# Altium schematic sheet FPGA_part3.SchDoc
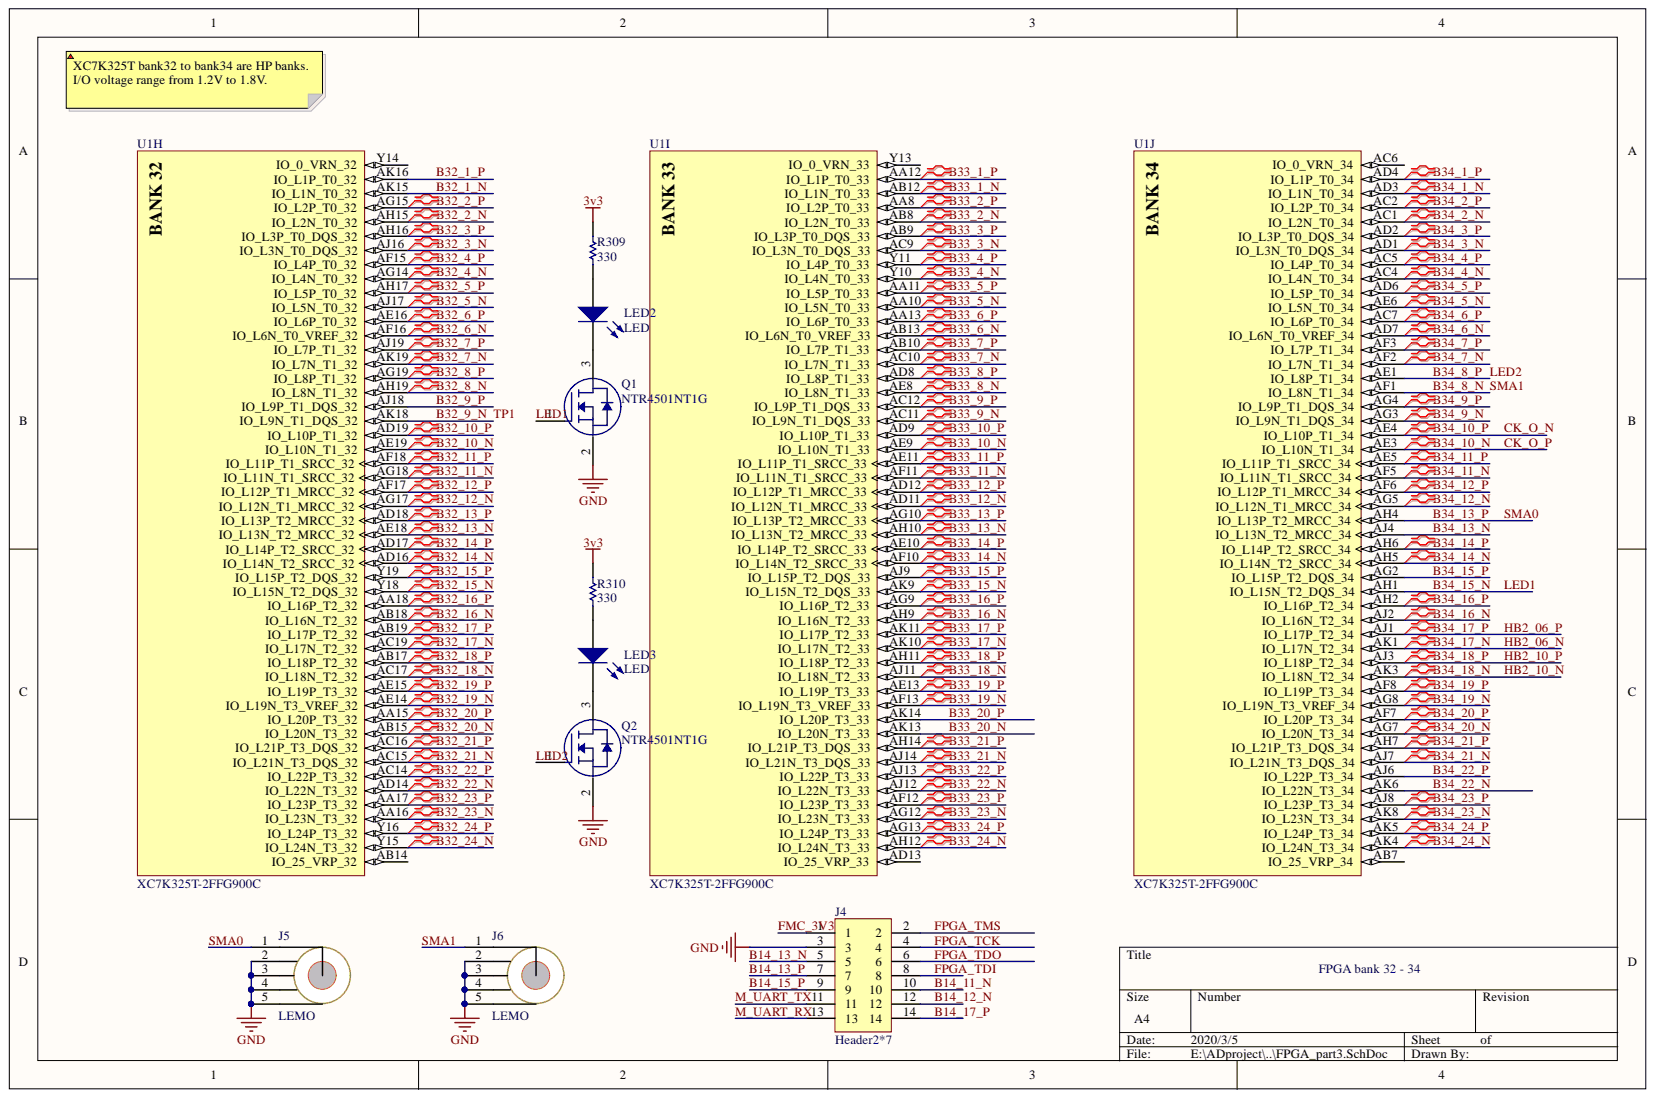
[diagram p0: FPGA_part3.SchDoc]

## Parts
J4  Header2*7  [HDR2X7]
J5  LEMO  [LEMO_00]
J6  LEMO  [LEMO_00]
LED2  LED  [led_0603r]
LED3  LED  [led_0603r]
Q1  NTR4501NT1G  [sot-23]
Q2  NTR4501NT1G  [sot-23]
R309  value 330  [0402]
R310  value 330  [0402]
U1  XC7K325T-2FFG900C  Generic Part Number: XC7K325T  [FFG900]  (parts H,I,J)

## Nets
3v3: R309.2 R310.2
GND: J4.3 J5.2 J5.3 J5.4 J5.5 J6.2 J6.3 J6.4 J6.5 Q1.2 Q2.2
B14_11_N: J4.10
B14_12_N: J4.12
B14_13_N: J4.5
B14_13_P: J4.7
B14_15_P: J4.9
B14_17_P: J4.14
B32_1_N: U1.AK15(IO_L1N_T0_32)
B32_1_P: U1.AK16(IO_L1P_T0_32)
B32_2_N: U1.AH15(IO_L2N_T0_32)
B32_2_P: U1.AG15(IO_L2P_T0_32)
B32_3_N: U1.AJ16(IO_L3N_T0_DQS_32)
B32_3_P: U1.AH16(IO_L3P_T0_DQS_32)
B32_4_N: U1.AG14(IO_L4N_T0_32)
B32_4_P: U1.AF15(IO_L4P_T0_32)
B32_5_N: U1.AJ17(IO_L5N_T0_32)
B32_5_P: U1.AH17(IO_L5P_T0_32)
B32_6_N: U1.AF16(IO_L6N_T0_VREF_32)
B32_6_P: U1.AE16(IO_L6P_T0_32)
B32_7_N: U1.AK19(IO_L7N_T1_32)
B32_7_P: U1.AJ19(IO_L7P_T1_32)
B32_8_N: U1.AH19(IO_L8N_T1_32)
B32_8_P: U1.AG19(IO_L8P_T1_32)
B32_9_N: U1.AK18(IO_L9N_T1_DQS_32)
B32_9_P: U1.AJ18(IO_L9P_T1_DQS_32)
B32_10_N: U1.AE19(IO_L10N_T1_32)
B32_10_P: U1.AD19(IO_L10P_T1_32)
B32_11_N: U1.AG18(IO_L11N_T1_SRCC_32)
B32_11_P: U1.AF18(IO_L11P_T1_SRCC_32)
B32_12_N: U1.AG17(IO_L12N_T1_MRCC_32)
B32_12_P: U1.AF17(IO_L12P_T1_MRCC_32)
B32_13_N: U1.AE18(IO_L13N_T2_MRCC_32)
B32_13_P: U1.AD18(IO_L13P_T2_MRCC_32)
B32_14_N: U1.AD16(IO_L14N_T2_SRCC_32)
B32_14_P: U1.AD17(IO_L14P_T2_SRCC_32)
B32_15_N: U1.Y18(IO_L15N_T2_DQS_32)
B32_15_P: U1.Y19(IO_L15P_T2_DQS_32)
B32_16_N: U1.AB18(IO_L16N_T2_32)
B32_16_P: U1.AA18(IO_L16P_T2_32)
B32_17_N: U1.AC19(IO_L17N_T2_32)
B32_17_P: U1.AB19(IO_L17P_T2_32)
B32_18_N: U1.AC17(IO_L18N_T2_32)
B32_18_P: U1.AB17(IO_L18P_T2_32)
B32_19_N: U1.AE14(IO_L19N_T3_VREF_32)
B32_19_P: U1.AE15(IO_L19P_T3_32)
B32_20_N: U1.AB15(IO_L20N_T3_32)
B32_20_P: U1.AA15(IO_L20P_T3_32)
B32_21_N: U1.AC15(IO_L21N_T3_DQS_32)
B32_21_P: U1.AC16(IO_L21P_T3_DQS_32)
B32_22_N: U1.AD14(IO_L22N_T3_32)
B32_22_P: U1.AC14(IO_L22P_T3_32)
B32_23_N: U1.AA16(IO_L23N_T3_32)
B32_23_P: U1.AA17(IO_L23P_T3_32)
B32_24_N: U1.Y15(IO_L24N_T3_32)
B32_24_P: U1.Y16(IO_L24P_T3_32)
B33_1_N: U1.AB12(IO_L1N_T0_33)
B33_1_P: U1.AA12(IO_L1P_T0_33)
B33_2_N: U1.AB8(IO_L2N_T0_33)
B33_2_P: U1.AA8(IO_L2P_T0_33)
B33_3_N: U1.AC9(IO_L3N_T0_DQS_33)
B33_3_P: U1.AB9(IO_L3P_T0_DQS_33)
B33_4_N: U1.Y10(IO_L4N_T0_33)
B33_4_P: U1.Y11(IO_L4P_T0_33)
B33_5_N: U1.AA10(IO_L5N_T0_33)
B33_5_P: U1.AA11(IO_L5P_T0_33)
B33_6_N: U1.AB13(IO_L6N_T0_VREF_33)
B33_6_P: U1.AA13(IO_L6P_T0_33)
B33_7_N: U1.AC10(IO_L7N_T1_33)
B33_7_P: U1.AB10(IO_L7P_T1_33)
B33_8_N: U1.AE8(IO_L8N_T1_33)
B33_8_P: U1.AD8(IO_L8P_T1_33)
B33_9_N: U1.AC11(IO_L9N_T1_DQS_33)
B33_9_P: U1.AC12(IO_L9P_T1_DQS_33)
B33_10_N: U1.AE9(IO_L10N_T1_33)
B33_10_P: U1.AD9(IO_L10P_T1_33)
B33_11_N: U1.AF11(IO_L11N_T1_SRCC_33)
B33_11_P: U1.AE11(IO_L11P_T1_SRCC_33)
B33_12_N: U1.AD11(IO_L12N_T1_MRCC_33)
B33_12_P: U1.AD12(IO_L12P_T1_MRCC_33)
B33_13_N: U1.AH10(IO_L13N_T2_MRCC_33)
B33_13_P: U1.AG10(IO_L13P_T2_MRCC_33)
B33_14_N: U1.AF10(IO_L14N_T2_SRCC_33)
B33_14_P: U1.AE10(IO_L14P_T2_SRCC_33)
B33_15_N: U1.AK9(IO_L15N_T2_DQS_33)
B33_15_P: U1.AJ9(IO_L15P_T2_DQS_33)
B33_16_N: U1.AH9(IO_L16N_T2_33)
B33_16_P: U1.AG9(IO_L16P_T2_33)
B33_17_N: U1.AK10(IO_L17N_T2_33)
B33_17_P: U1.AK11(IO_L17P_T2_33)
B33_18_N: U1.AJ11(IO_L18N_T2_33)
B33_18_P: U1.AH11(IO_L18P_T2_33)
B33_19_N: U1.AF13(IO_L19N_T3_VREF_33)
B33_19_P: U1.AE13(IO_L19P_T3_33)
B33_20_N: U1.AK13(IO_L20N_T3_33)
B33_20_P: U1.AK14(IO_L20P_T3_33)
B33_21_N: U1.AJ14(IO_L21N_T3_DQS_33)
B33_21_P: U1.AH14(IO_L21P_T3_DQS_33)
B33_22_N: U1.AJ12(IO_L22N_T3_33)
B33_22_P: U1.AJ13(IO_L22P_T3_33)
B33_23_N: U1.AG12(IO_L23N_T3_33)
B33_23_P: U1.AF12(IO_L23P_T3_33)
B33_24_N: U1.AH12(IO_L24N_T3_33)
B33_24_P: U1.AG13(IO_L24P_T3_33)
B34_1_N: U1.AD3(IO_L1N_T0_34)
B34_1_P: U1.AD4(IO_L1P_T0_34)
B34_2_N: U1.AC1(IO_L2N_T0_34)
B34_2_P: U1.AC2(IO_L2P_T0_34)
B34_3_N: U1.AD1(IO_L3N_T0_DQS_34)
B34_3_P: U1.AD2(IO_L3P_T0_DQS_34)
B34_4_N: U1.AC4(IO_L4N_T0_34)
B34_4_P: U1.AC5(IO_L4P_T0_34)
B34_5_N: U1.AE6(IO_L5N_T0_34)
B34_5_P: U1.AD6(IO_L5P_T0_34)
B34_6_N: U1.AD7(IO_L6N_T0_VREF_34)
B34_6_P: U1.AC7(IO_L6P_T0_34)
B34_7_N: U1.AF2(IO_L7N_T1_34)
B34_7_P: U1.AF3(IO_L7P_T1_34)
B34_8_N: J6.1 U1.AF1(IO_L8N_T1_34)
B34_8_P: Q2.1 U1.AE1(IO_L8P_T1_34)
B34_9_N: U1.AG3(IO_L9N_T1_DQS_34)
B34_9_P: U1.AG4(IO_L9P_T1_DQS_34)
B34_10_N: U1.AE3(IO_L10N_T1_34)
B34_10_P: U1.AE4(IO_L10P_T1_34)
B34_11_N: U1.AF5(IO_L11N_T1_SRCC_34)
B34_11_P: U1.AE5(IO_L11P_T1_SRCC_34)
B34_12_N: U1.AG5(IO_L12N_T1_MRCC_34)
B34_12_P: U1.AF6(IO_L12P_T1_MRCC_34)
B34_13_N: U1.AJ4(IO_L13N_T2_MRCC_34)
B34_13_P: J5.1 U1.AH4(IO_L13P_T2_MRCC_34)
B34_14_N: U1.AH5(IO_L14N_T2_SRCC_34)
B34_14_P: U1.AH6(IO_L14P_T2_SRCC_34)
B34_15_N: Q1.1 U1.AH1(IO_L15N_T2_DQS_34)
B34_15_P: U1.AG2(IO_L15P_T2_DQS_34)
B34_16_N: U1.AJ2(IO_L16N_T2_34)
B34_16_P: U1.AH2(IO_L16P_T2_34)
B34_17_N: U1.AK1(IO_L17N_T2_34)
B34_17_P: U1.AJ1(IO_L17P_T2_34)
B34_18_N: U1.AK3(IO_L18N_T2_34)
B34_18_P: U1.AJ3(IO_L18P_T2_34)
B34_19_N: U1.AG8(IO_L19N_T3_VREF_34)
B34_19_P: U1.AF8(IO_L19P_T3_34)
B34_20_N: U1.AG7(IO_L20N_T3_34)
B34_20_P: U1.AF7(IO_L20P_T3_34)
B34_21_N: U1.AJ7(IO_L21N_T3_DQS_34)
B34_21_P: U1.AH7(IO_L21P_T3_DQS_34)
B34_22_N: U1.AK6(IO_L22N_T3_34)
B34_22_P: U1.AJ6(IO_L22P_T3_34)
B34_23_N: U1.AK8(IO_L23N_T3_34)
B34_23_P: U1.AJ8(IO_L23P_T3_34)
B34_24_N: U1.AK4(IO_L24N_T3_34)
B34_24_P: U1.AK5(IO_L24P_T3_34)
FMC_3V3: J4.1
FPGA_TCK: J4.4
FPGA_TDI: J4.8
FPGA_TDO: J4.6
FPGA_TMS: J4.2
M_UART_RX: J4.13
M_UART_TX: J4.11
NetLED2_1: LED2.1 Q1.3
NetLED2_2: LED2.2 R309.1
NetLED3_1: LED3.1 Q2.3
NetLED3_2: LED3.2 R310.1

Not connected: U1 AB7(IO_25_VRP_34) AB14(IO_25_VRP_32) AC6(IO_0_VRN_34) AD13(IO_25_VRP_33) Y13(IO_0_VRN_33) Y14(IO_0_VRN_32)

## Library data of the parts
Q1 Q2 = N-MOSFET: Component Kind: Standard; Library Name: general.SchLib
R309 R310 = CMP-1012-00454-1: Case-EIA: 0603; Case-Metric: 1608; Power: 0.1 W; Tolerance: 1 %; Component Kind: Standard; Library Name: Altium Content Vault; Technology: SMT
U1 = CMP-0361-00090-2: Kintex-7 FPGA, 500 User I/Os, 16 GTX, 900-Ball BGA, Speed Grade 2, Commerical Grade, Pb-Free; Manufacturer: Xilinx; GTX: 16; SelectIO HP: 150; SelectIO HR: 350; Speed Grade: -2; Code_JEDEC: MS-034-AAN-1; Component Kind: Standard; ComponentLink1Description: Datasheet; ComponentLink1URL: http://www.xilinx.com/support/documentation/data_sheets/ds180_7Series_Overview.pdf; ComponentLink2Description: Package Specification; ComponentLink2URL: http://www.xilinx.com/support/documentation/user_guides/ug865-Zynq-7000-Pkg-Pinout.pdf; DatasheetVersion: v1.12; Library Name: Altium Content Vault; Mounting Technology: Surface Mount; PackageDescription: Flip-Chip BGA, Body 31 x 31 mm, 1.0 mm Pitch; PackageReference: FFG900; PackageVersion: Rev 1.1; PartId: 1; PartNumber: XC7K325T-2FFG900C; RoHS: Pb-Free
J4 = Header2*7: Component Kind: Standard; Library Name: general.SchLib
J5 J6 = LEMO: Component Kind: Standard; Library Name: general.SchLib
LED2 LED3 = LED: Component Kind: Standard; Library Name: general.SchLib
